# Altium schematic sheet [11] - SENSORS.SchDoc
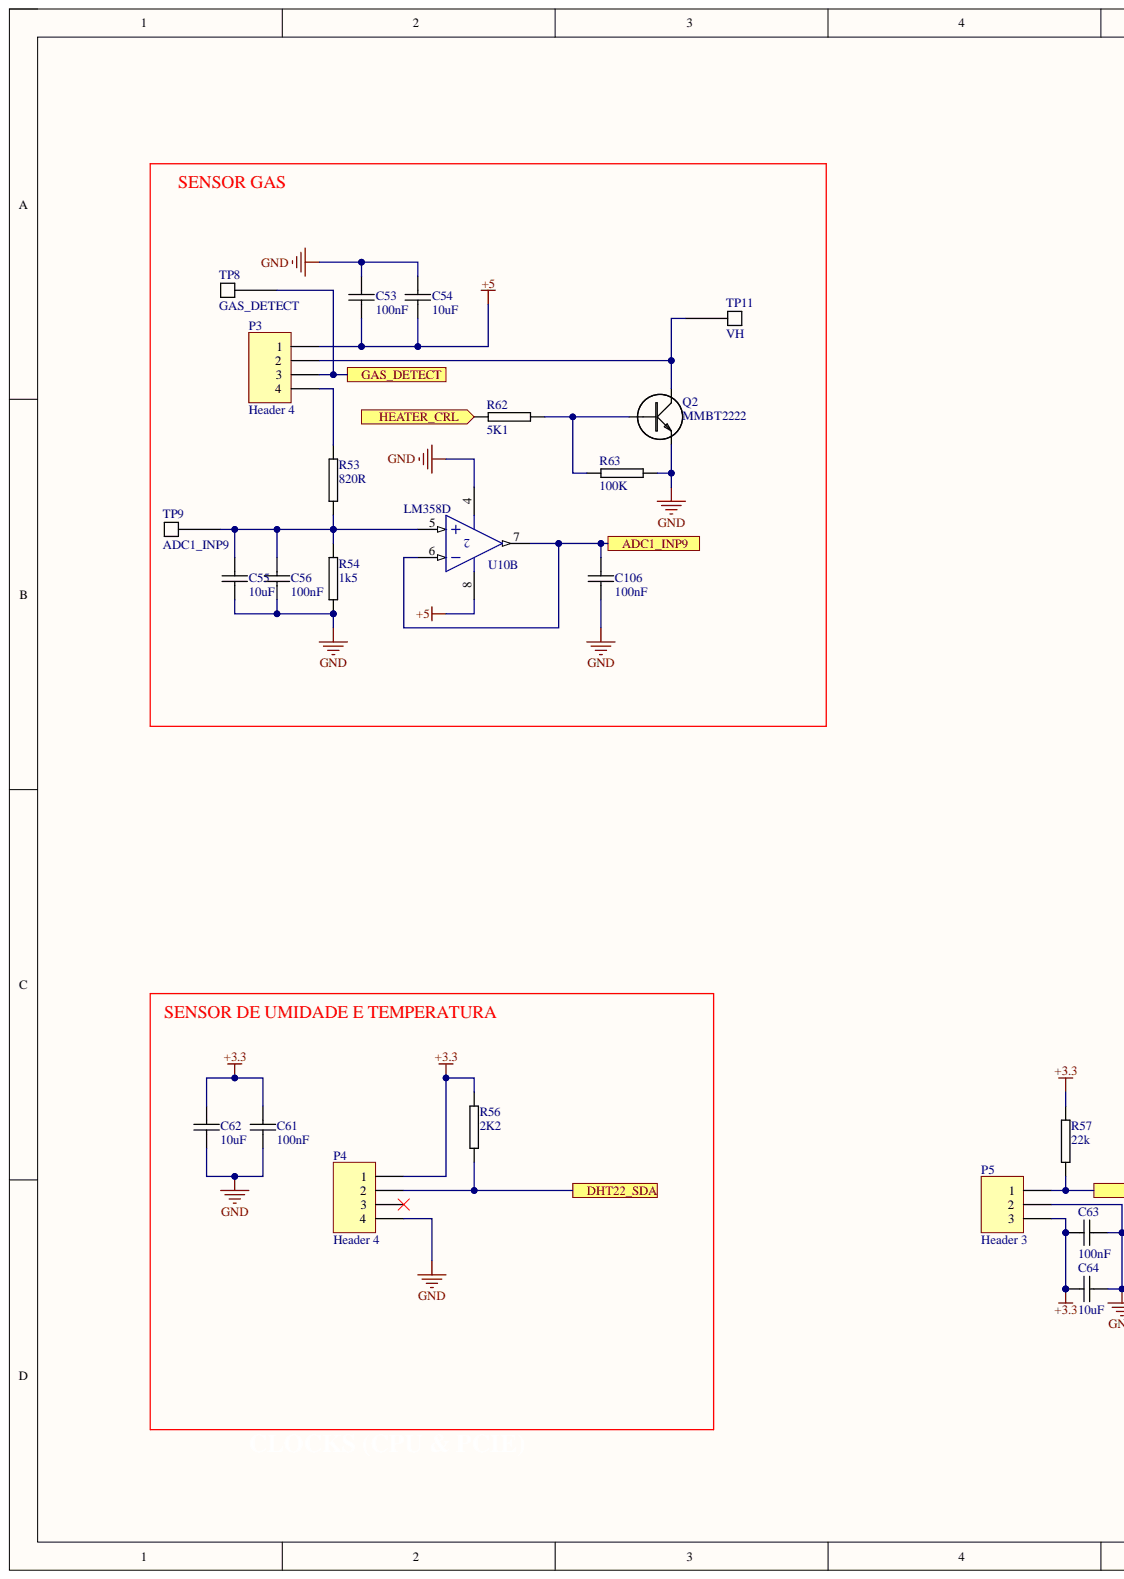
[diagram p0: [11] - SENSORS.SchDoc, left]
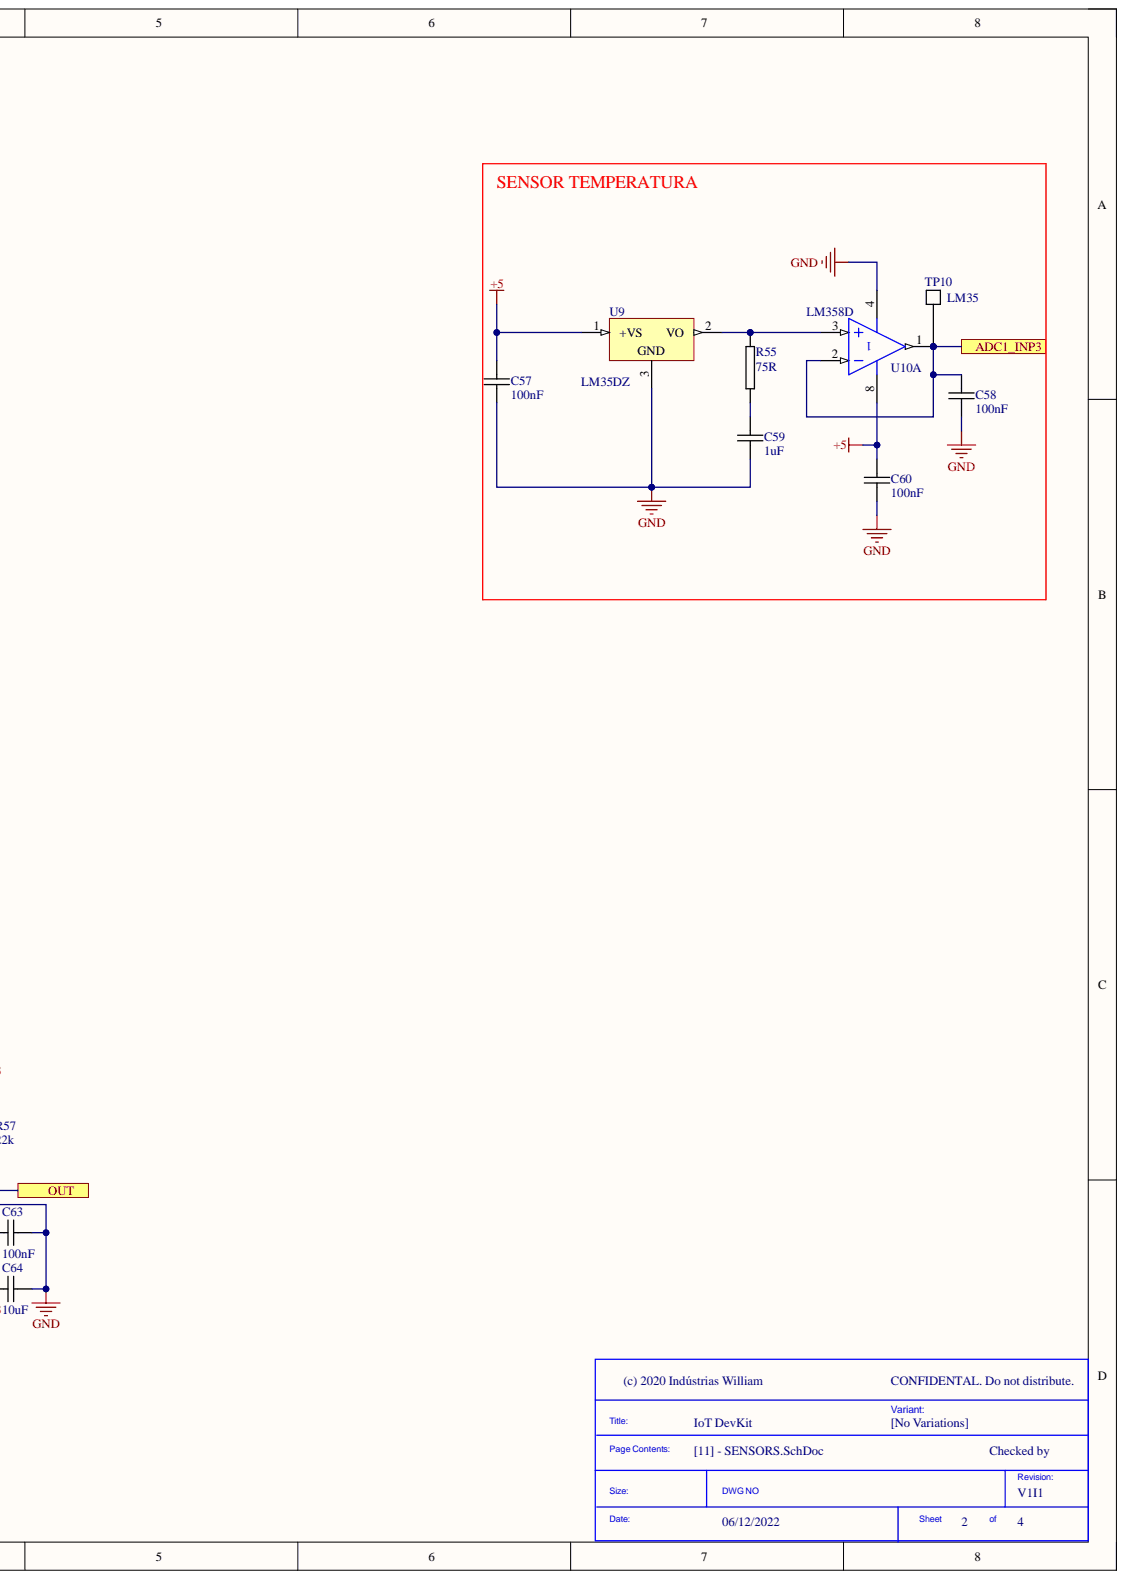
[diagram p1: [11] - SENSORS.SchDoc, right]

## Parts
C53  100nF  [0603C-GENERIC]
C54  10uF  [0603C-GENERIC]
C55  10uF  [0603C-GENERIC]
C56  100nF  [0603C-GENERIC]
C57  100nF  [0603C-GENERIC]
C58  100nF  [0603C-GENERIC]
C59  1uF  [0603C-GENERIC]
C60  100nF  [0603C-GENERIC]
C61  100nF  [0603C-GENERIC]
C62  10uF  [0603C-GENERIC]
C63  100nF  [0603C-GENERIC]
C64  10uF  [0603C-GENERIC]
C106  100nF  [0603C-GENERIC]
P3  Header 4  [HDR1X4]
P4  Header 4  [HDR1X4]
P5  Header 3  [HDR1X3]
Q2  MMBT2222  [SOT23-BCE]
R53  820R  [0603R-1k]
R54  1k5  [0603R-1k]
R55  75R  [0603R-TBD]
R56  2K2  [0603R-2K2]
R57  22k  [0603R-GENERIC]
R62  5K1  [0603R-GENERIC]
R63  100K  [0603R-100K]
TP8  GAS_DETECT  [TestPoint]
TP9  ADC1_INP9  [TestPoint]
TP10  LM35  [TestPoint]
TP11  VH  [TestPoint]
U9  LM35DZ  [Z03A]
U10  LM358D  [751-02_N]  (parts A,B)

## Ports
ADC1_INP3, ADC1_INP9, DHT22_SDA, GAS_DETECT, HEATER_CRL, OUT

## Nets
+3.3: C61.2 C62.2 C63.1 C64.1 P4.1 P5.3 R56.1 R57.1
+5: C53.1 C54.1 C57.1 C60.2 P3.1 U9.1 U10.8
GND: C53.2 C54.2 C55.1 C56.1 C57.2 C58.1 C59.2 C60.1 C61.1 C62.1 C63.2 C64.2 C106.1 P4.4 P5.2 Q2.E R54.2 R63.2 U9.3 U10.4
ADC1_INP3: C58.2 TP10.1 U10.1 U10.2 ; port ADC1_INP3
ADC1_INP9: C106.2 U10.6 U10.7 ; port ADC1_INP9
DHT22_SDA: P4.2 R56.2 ; port DHT22_SDA
GAS_DETECT: P3.3 TP8.1 ; port GAS_DETECT
HEATER_CRL: R62.1 ; port HEATER_CRL
OUT: P5.1 R57.2 ; port OUT
NetC55_2: C55.2 C56.2 R53.2 R54.1 TP9.1 U10.5
NetC59_1: C59.1 R55.2
NetP3_2: P3.2 Q2.C TP11.1
NetP3_4: P3.4 R53.1
NetQ2_B: Q2.B R62.2 R63.1
NetR55_1: R55.1 U9.2 U10.3

Not connected: P4 3

## Library data of the parts
C53 C56-C58 C60 C61 C63 C106 = 0603C-100NF-25V-X7R-TDK: CAPACITOR 0603 CERAMIC; Manufacturer: TDK Corporation; Manufacturer Part Number: C1608X7R1E104K; Reel: C12; Component Kind: Standard; Library Name: vh_altium_library.intLib; Supplier 1: Digi-Key; Supplier Part Number 1: 445-1316-2-ND
C54 C55 C62 C64 = 0603C-10UF-10V-X5R-TDK: CAPACITOR 0603 CERAMIC; Manufacturer: TDK Corporation; Manufacturer Part Number: C1608X5R0J106M; Reel: C31; Component Kind: Standard; Library Name: vh_altium_library.intLib; Supplier 1: Digi-Key; Supplier Part Number 1: 445-4112-2-ND
C59 = 0603C-1UF-10V-X5R-TDK: CAPACITOR 0603 CERAMIC; Manufacturer: TDK Corporation; Manufacturer Part Number: C1608X5R1A105K; Reel: C23; Component Kind: Standard; Library Name: vh_altium_library.intLib; Supplier 1: Digi-Key; Supplier Part Number 1: 445-1321-2-ND
P3 P4 = Header 4: Header, 4-Pin; Component Kind: Standard; LatestRevisionDate: 17-Jul-2002; LatestRevisionNote: Re-released for DXP Platform.; Library Name: Miscellaneous Connectors.IntLib; Signal Integrity: Connector; Publisher: Altium Limited
P5 = Header 3: Header, 3-Pin; Component Kind: Standard; LatestRevisionDate: 17-Jul-2002; LatestRevisionNote: Re-released for DXP Platform.; Library Name: Miscellaneous Connectors.IntLib; Signal Integrity: Connector; Publisher: Altium Limited
Q2 = MMBT2222: BC847 SMALL SIGNAL NPN TRANSISTOR; Component Kind: Standard; Library Name: vh_altium_library.intLib; Supplier 1: Digi-Key; Supplier Part Number 1: MMBT2222A-FDICT-ND
R53 R54 = 0603R-1K: GENERIC RESISTOR 0603; Manufacturer: Stackpole Electronics Inc; Manufacturer Part Number: RMCF 1/16 1K 1% R; Reel: R14; Component Kind: Standard; Library Name: vh_altium_library.intLib; Supplier 1: Digi-Key; Supplier Part Number 1: RMCF0603FT1K00TR-ND
R55 = 0603R-475: GENERIC RESISTOR 0603; Manufacturer: Stackpole Electronics Inc; Manufacturer Part Number: RMCF 1/16 475 1% R; Power (Watts): 0.1W, 1/10W; Reel: R29; Resistance In Ohms: 475; Temperature Coefficient: ±100ppm/°C; Tolerance: ±1%; Case: 0603 (1608 metric); Category: Resistors; Component Kind: Standard; Composition: Thick Film; Lead Style: Surface Mount (SMD - SMT); Library Name: vh_altium_library.intLib; Packaging: Tape & Reel (TR); RoHS: RoHS Compliant; Supplier: Digi-Key; Supplier 1: Digi-Key; Supplier Part Number: RMCF1/16475FRTR-ND; Supplier Part Number 1: RMCF1/16475FRTR-ND
R56 = 0603R-2K2: GENERIC RESISTOR 0603; Manufacturer: Stackpole Electronics Inc; Manufacturer Part Number: RMC 1/16 2.2K 1% R; REEL: R88; Component Kind: Standard; Library Name: vh_altium_library.intLib; Supplier 1: Digi-Key; Supplier Part Number 1: RMCF0603FT2K20TR-ND
R57 R62 = 0603R-5K1: GENERIC RESISTOR 0603; Manufacturer: Stackpole Electronics Inc; Manufacturer Part Number: RMCF 1/16 15K 1% R; Power (Watts): 0.1W, 1/10W; Resistance In Ohms: 15.0K; Temperature Coefficient: ±100ppm/°C; Tolerance: ±1%; Case: 0603 (1608 metric); Category: Resistors; Component Kind: Standard; Composition: Thick Film; Lead Style: Surface Mount (SMD - SMT); Library Name: vh_altium_library.intLib; Packaging: Tape & Reel (TR); RoHS: RoHS Compliant; Supplier: Digi-Key; Supplier 1: Digi-Key; Supplier Part Number: RMCF1/1615KFRTR-ND; Supplier Part Number 1: RMCF1/1615KFRTR-ND
R63 = 0603R-100K: GENERIC RESISTOR 0603; Manufacturer: Stackpole Electronics Inc; Manufacturer Part Number: RMCF 1/16 100K 1% R; Power (Watts): 0.1W, 1/10W; REEL: R47; Temperature Coefficient: ±100ppm/°C; Tolerance: ±1%; Case: 0603 (1608 metric); Category: Resistors; Component Kind: Standard; Composition: Thick Film; Lead Style: Surface Mount (SMD - SMT); Library Name: vh_altium_library.intLib; Packaging: Cut Tape (CT); Resistance In Ohms: 100K; RoHS: RoHS Compliant; Supplier: Digi-Key; Supplier 1: Digi-Key; Supplier Part Number: RMCF1/16100KFRCT-ND; Supplier Part Number 1: RMCF0603FT100KTR-ND
TP8-TP11 = TestPoint: TESTE POINT; Component Kind: Standard; Library Name: Componentes Deived Projetos.IntLib
U9 = LM35DZ: Precision Centigrade Temperature Sensor, 3-pin TO-92; Manufacturer: National Semiconductor; CompType: Temp Sensor IC; PackageTopMarking: NSXYTT*LM35*DZ; PowerWise: N; Code_JEDEC: TO-226-AA; Component Kind: Standard; ComponentLink1Description: LM35 Datasheet; ComponentLink1URL: http://www.national.com/ds/LM/LM35.pdf; ComponentLink2Description: LM35 Web Page; ComponentLink2URL: http://www.national.com/pf/LM/LM35.html; ComponentLink3Description: Z03A Package Page; ComponentLink3URL: http://www.national.com/packaging/folders/z03a.html; DatasheetVersion: Nov-2000; LatestRevisionDate: 15-Jul-2009; LatestRevisionNote: Sch pins 1 & 3 reversed.; Library Name: NSC Temperature Sensor.IntLib; PackageDescription: TO-92, Molded, 3 Lead; PackageReference: Z03A; PackageVersion: revG, Sep-2000; PartNumber: LM35DZ; PinCount: 3; Published: 4-Dec-2008; RoHS: N; Supplier 1: Farnell; Supplier Part Number 1: 9488200; Publisher: Altium Limited
U10 = LM358D: Dual Low-Power Operational Amplifier; Code_IPC: SOIC127P600-8; Code_JEDEC: MS-012-AA; Component Kind: Standard; ComponentLink1Description: Manufacturer Link; ComponentLink1URL: http://www.onsemi.com/site/products/parametrics/0,4446,251,00.html; ComponentLink2Description: Datasheet; ComponentLink2URL: http://www.onsemi.com/pub/Collateral/LM358-D.PDF; DatasheetVersion: 1988; LatestRevisionDate: 13-Apr-2006; LatestRevisionNote: IPC-7351 Footprint Added.; Library Name: Motorola Amplifier Operational Amplifier.IntLib; PackageDescription: 8-Pin Small Outline Integrated Circuit 1.27 mm Pitch; PackageReference: 751-02; PCB3D: 751-02; Published: 17-Jul-2002; Publisher: Altium Limited
